# Altium schematic sheet LCD and buttons.SchDoc
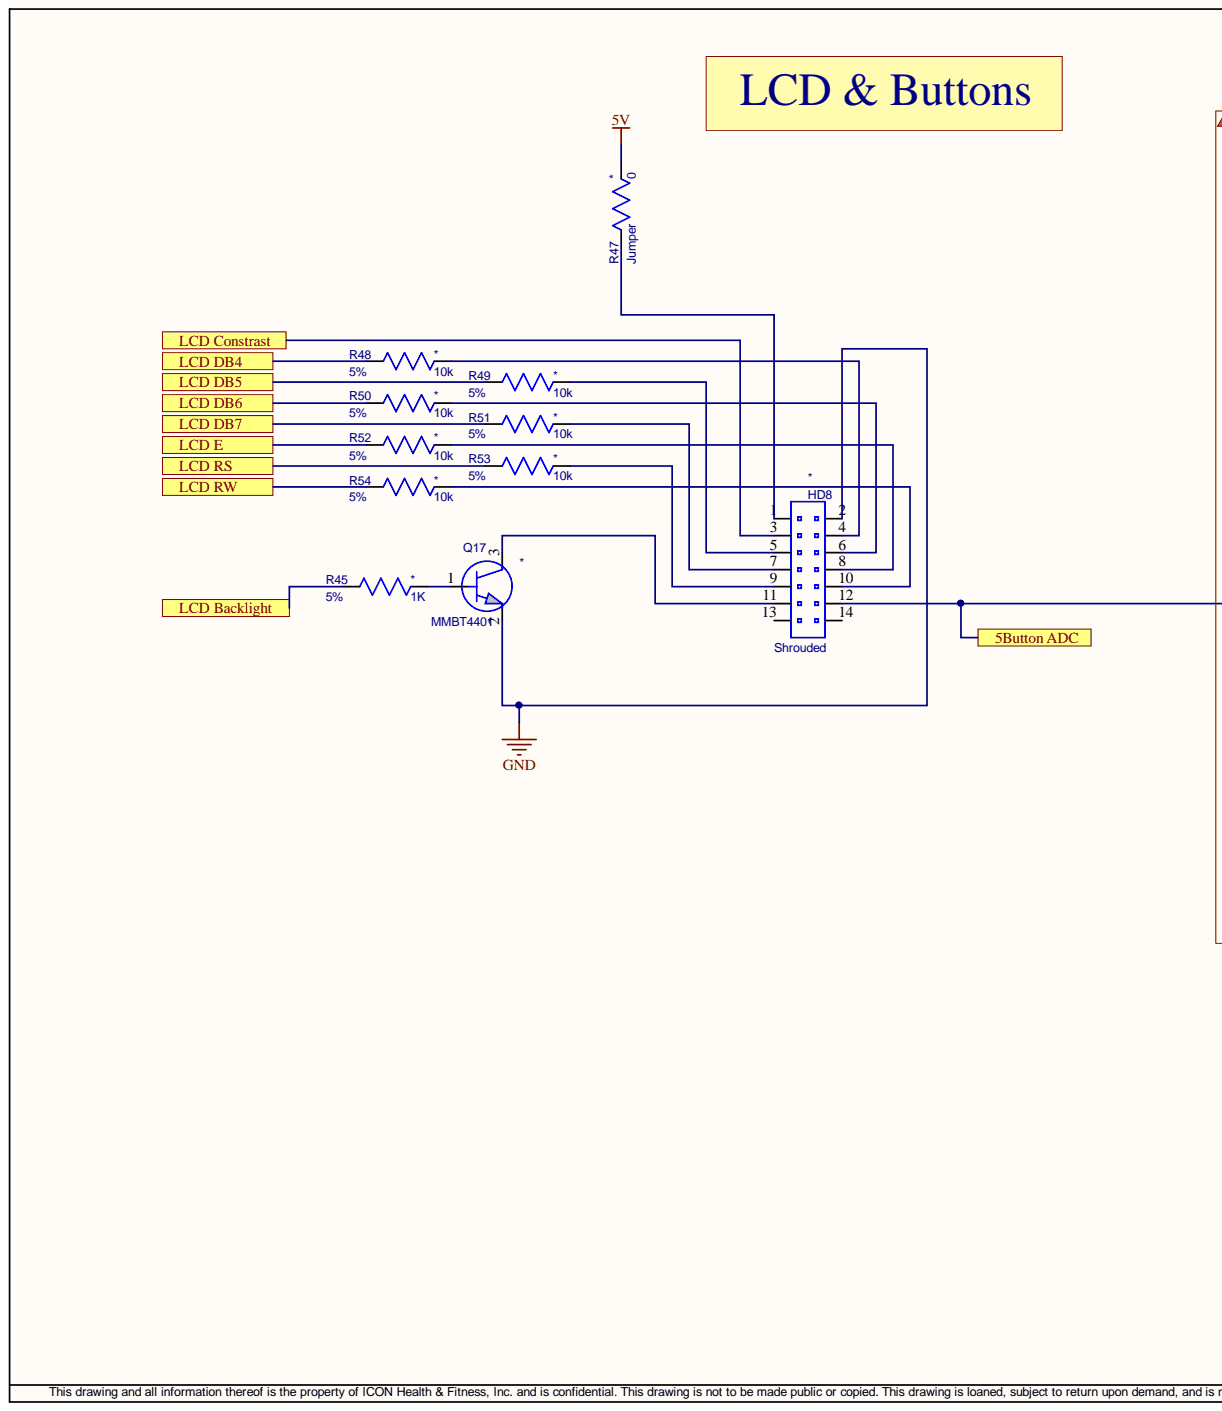
[diagram p0: LCD and buttons.SchDoc, left]
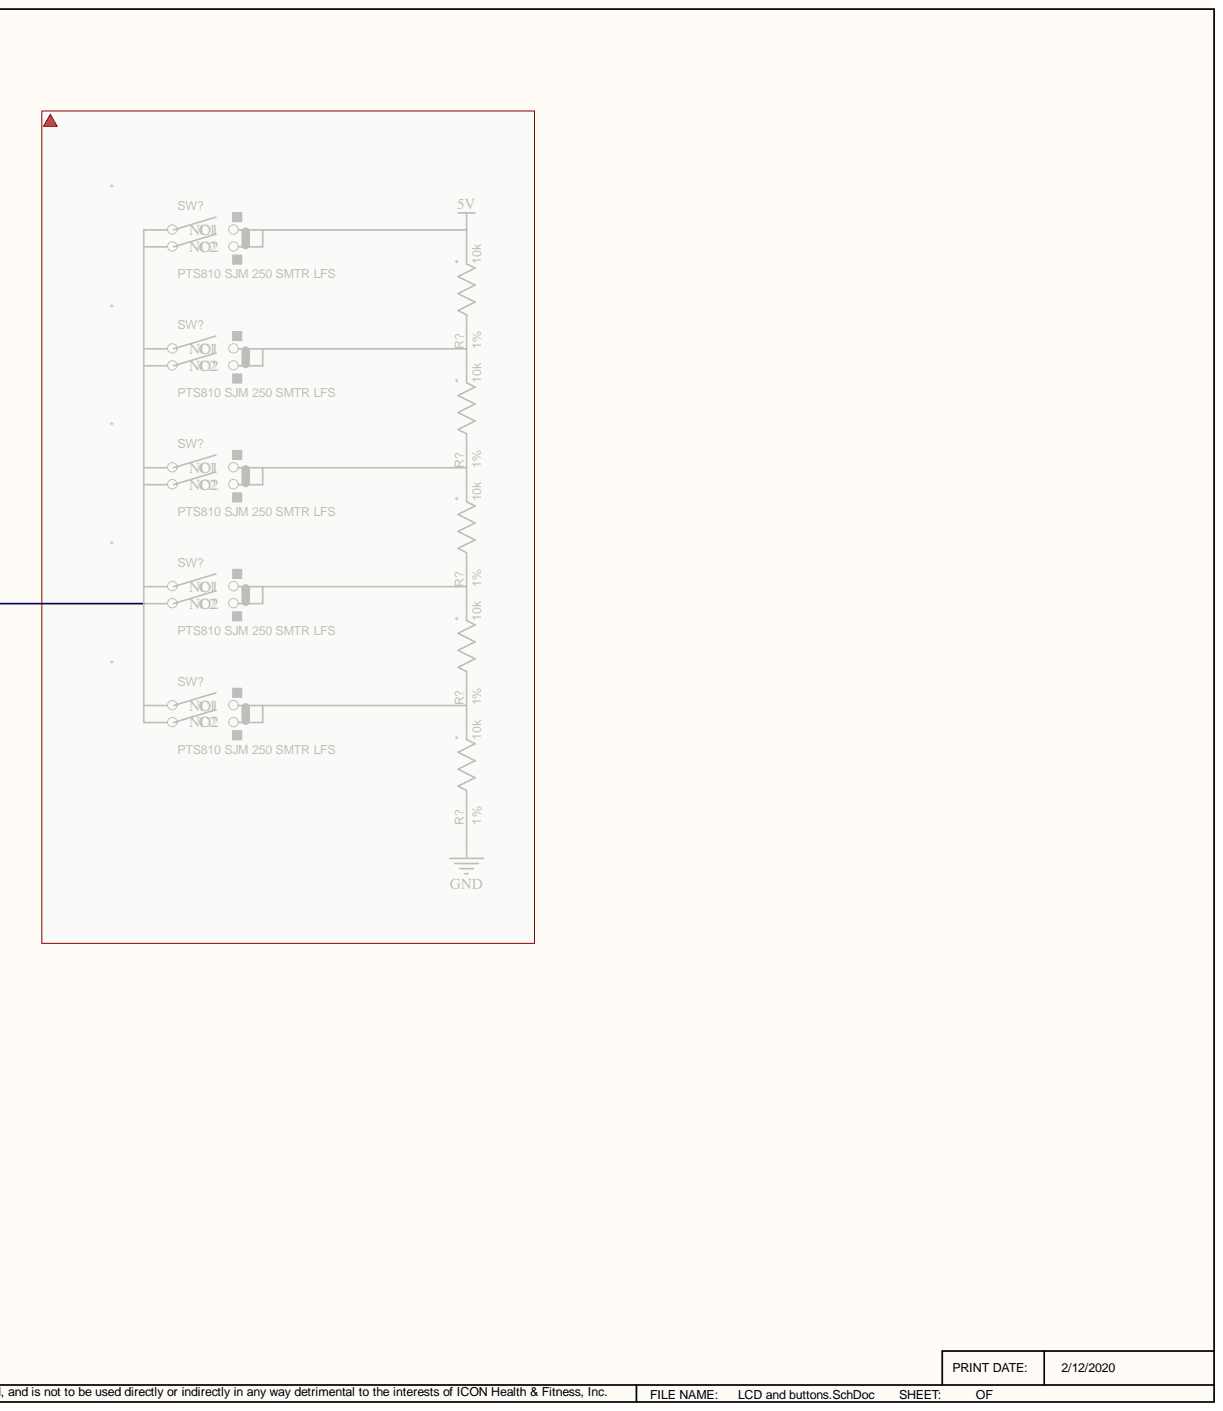
[diagram p1: LCD and buttons.SchDoc, right]

## Parts
HD8  HeaderType: Shrouded  [HDR_2.5MM_14PIN_JST_XAD]  (hidden comment: HDR_14PIN_DUALROW_JST)
Q17  value MMBT4401  [SOT23-3]  (hidden comment: TRANSISTOR_NPN_SOT23)
R45  value 1K  Tolerance: 5%  [0402]  (hidden comment: RESISTOR_SMT_5%)
R47  value 0  Tolerance: Jumper  [0603]  (hidden comment: RESISTOR_SMT_5%)
R48  value 10k  Tolerance: 5%  [0402]  (hidden comment: RESISTOR_SMT_5%)
R49  value 10k  Tolerance: 5%  [0402]  (hidden comment: RESISTOR_SMT_5%)
R50  value 10k  Tolerance: 5%  [0402]  (hidden comment: RESISTOR_SMT_5%)
R51  value 10k  Tolerance: 5%  [0402]  (hidden comment: RESISTOR_SMT_5%)
R52  value 10k  Tolerance: 5%  [0402]  (hidden comment: RESISTOR_SMT_5%)
R53  value 10k  Tolerance: 5%  [0402]  (hidden comment: RESISTOR_SMT_5%)
R54  value 10k  Tolerance: 5%  [0402]  (hidden comment: RESISTOR_SMT_5%)

## Ports
5Button ADC, LCD Backlight, LCD Constrast, LCD DB4, LCD DB5, LCD DB6, LCD DB7, LCD E, LCD RS, LCD RW

## Nets
5V: R47.1
GND: HD8.2 Q17.2
5Button ADC: HD8.12 ; port 5Button ADC
LCD Backlight: R45.2 ; port LCD Backlight
LCD Constrast: HD8.3 ; port LCD Constrast
LCD DB4: R48.2 ; port LCD DB4
LCD DB5: R49.2 ; port LCD DB5
LCD DB6: R50.2 ; port LCD DB6
LCD DB7: R51.2 ; port LCD DB7
LCD E: R52.2 ; port LCD E
LCD RS: R53.2 ; port LCD RS
LCD RW: R54.2 ; port LCD RW
NetHD8_1: HD8.1 R47.2
NetHD8_4: HD8.4 R48.1
NetHD8_5: HD8.5 R49.1
NetHD8_6: HD8.6 R50.1
NetHD8_7: HD8.7 R51.1
NetHD8_8: HD8.8 R52.1
NetHD8_9: HD8.9 R53.1
NetHD8_10: HD8.10 R54.1
NetHD8_11: HD8.11 Q17.3
NetQ17_1: Q17.1 R45.1

Not connected: HD8 13 14

## Library data of the parts
HD8 = 365385: Header, 14 Pins, 2.50mm, Vertical, Through hole, double row, Shrouded, JST: B14B-XADSS-N-A; Database Table Name: AltiumComponents; Component Kind: Standard; ComponentLink1Description: Datasheet; ComponentLink1URL: \\iconfitness.com\loganfiles\RND Departments\electronics\accel_lib\Datasheets\eXA1.pdf; ComponentLink4Description: PDM Parts Maintenance; ComponentLink4URL: https://pdm.iconfitness.com/PDM/EBOM/PartsMaintenance/PartsMaintenance.aspx?Editplidx=365385; ComponentType: Header; Cost: 0; LeadSpacing: 2.50mm; Package: Vertical, Through hole, double row; Pins: 14; plIDX: 365385; Value: B14B-XADSS-N-A; Vendor: JST: B14B-XADSS-N-A; CreatedBy: Ross Bunnell; LastUpdatedBy: Ross Bunnell; Library Name: bome.DbLib
Q17 = 265442: Transistor, MMBT4401, 40V, SOT23-3, 500mA, NPN, Transistor, On Semi: MMBT4401LT1; Database Table Name: AltiumComponents; Amperage: 500mA; ChipType: Transistor; Component Kind: Standard; ComponentLink4Description: PDM Parts Maintenance; ComponentLink4URL: https://pdm.iconfitness.com/PDM/EBOM/PartsMaintenance/PartsMaintenance.aspx?Editplidx=265442; ComponentType: Transistor; Cost: 0.0094; Package: SOT23-3; plIDX: 265442; TransistorType: NPN; Vendor: On Semi: MMBT4401LT1; Voltage: 40V; CheckedBy: gdeguzman; CreatedBy: Mike Tyger; LastUpdatedBy: Mike Tyger; Library Name: bome.DbLib
R45 = 22012: Resistor, 1K, ThickFilm, 5%, 0402, 1/10W, Any; Database Table Name: AltiumComponents; Component Kind: Standard; ComponentLink4Description: PDM Parts Maintenance; ComponentLink4URL: https://pdm.iconfitness.com/PDM/EBOM/PartsMaintenance/PartsMaintenance.aspx?Editplidx=22012; ComponentType: Resistor; Composition: ThickFilm; Cost: 0.001; Package: 0402; plIDX: 22012; Vendor: Any; Wattage: 1/10W; CheckedBy: gdeguzman; LastUpdatedBy: Mike Tyger; Library Name: bome.DbLib
R47 = 2045: Resistor, 0, ThickFilm, Jumper, 0603, 1/10W, Any; Database Table Name: AltiumComponents; Component Kind: Standard; ComponentLink4Description: PDM Parts Maintenance; ComponentLink4URL: https://pdm.iconfitness.com/PDM/EBOM/PartsMaintenance/PartsMaintenance.aspx?Editplidx=2045; ComponentType: Resistor; Composition: ThickFilm; Cost: 0.0004; Package: 0603; plIDX: 2045; Vendor: Any; Wattage: 1/10W; CheckedBy: gdeguzman; LastUpdatedBy: Mike Tyger; Library Name: bome.DbLib
R48-R54 = 19590: Resistor, 10k, ThickFilm, 5%, 0402, 1/10W, Any; Database Table Name: AltiumComponents; Component Kind: Standard; ComponentLink4Description: PDM Parts Maintenance; ComponentLink4URL: https://pdm.iconfitness.com/PDM/EBOM/PartsMaintenance/PartsMaintenance.aspx?Editplidx=19590; ComponentType: Resistor; Composition: ThickFilm; Cost: 0.0004; Package: 0402; plIDX: 19590; Vendor: Any; Wattage: 1/10W; CheckedBy: gdeguzman; LastUpdatedBy: Mike Tyger; Library Name: bome.DbLib
